# Altium schematic sheet StepperMotor.SchDoc
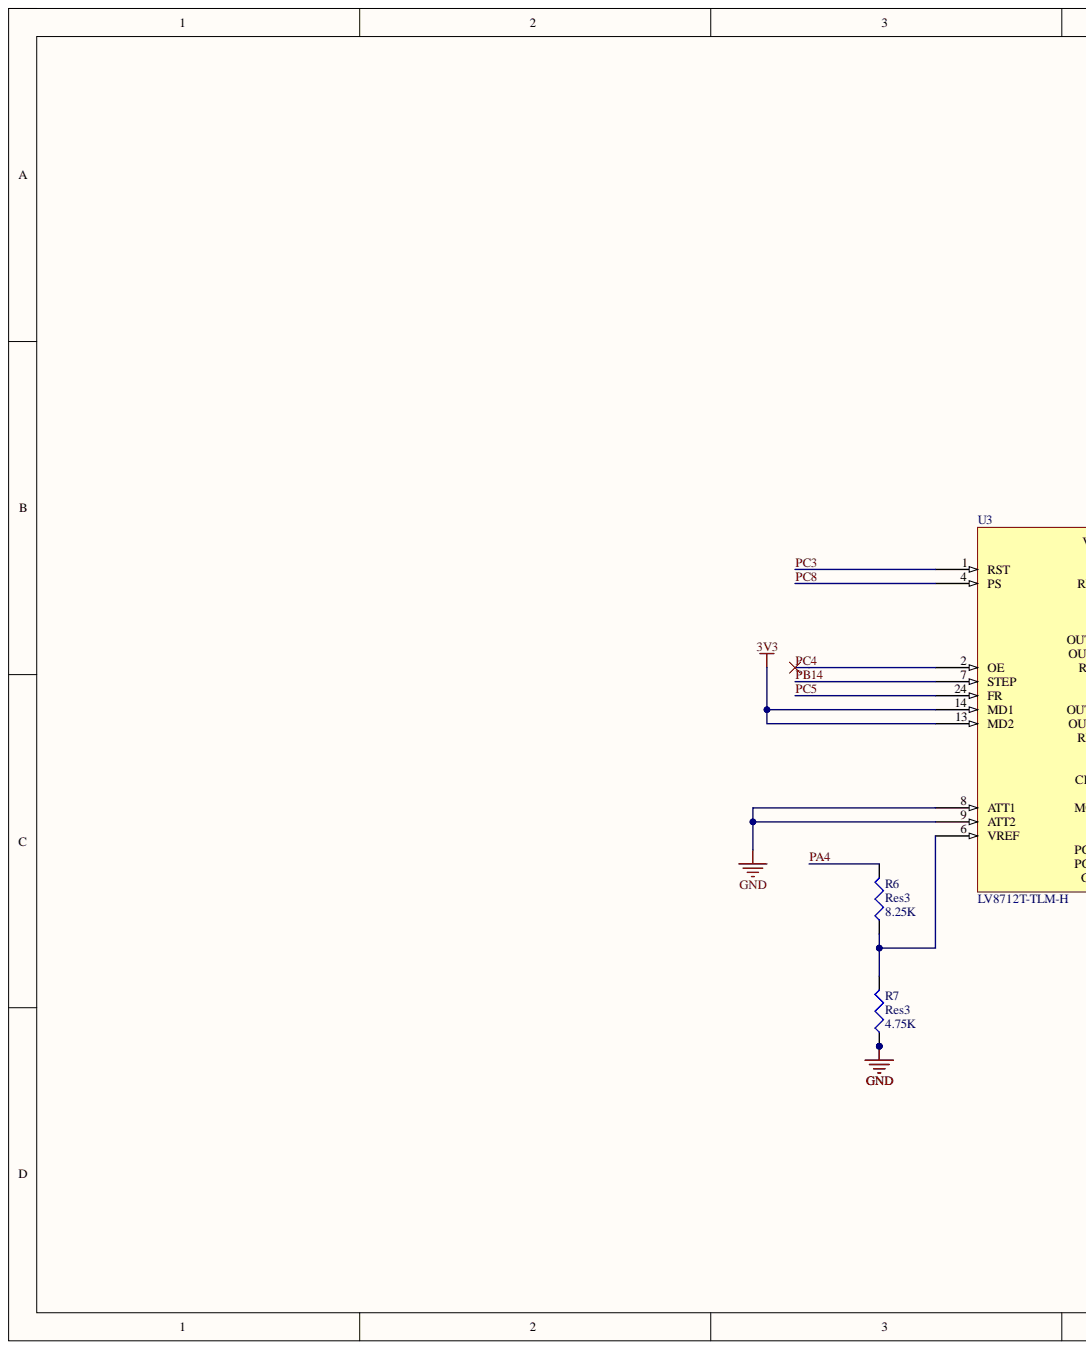
[diagram p0: StepperMotor.SchDoc, left]
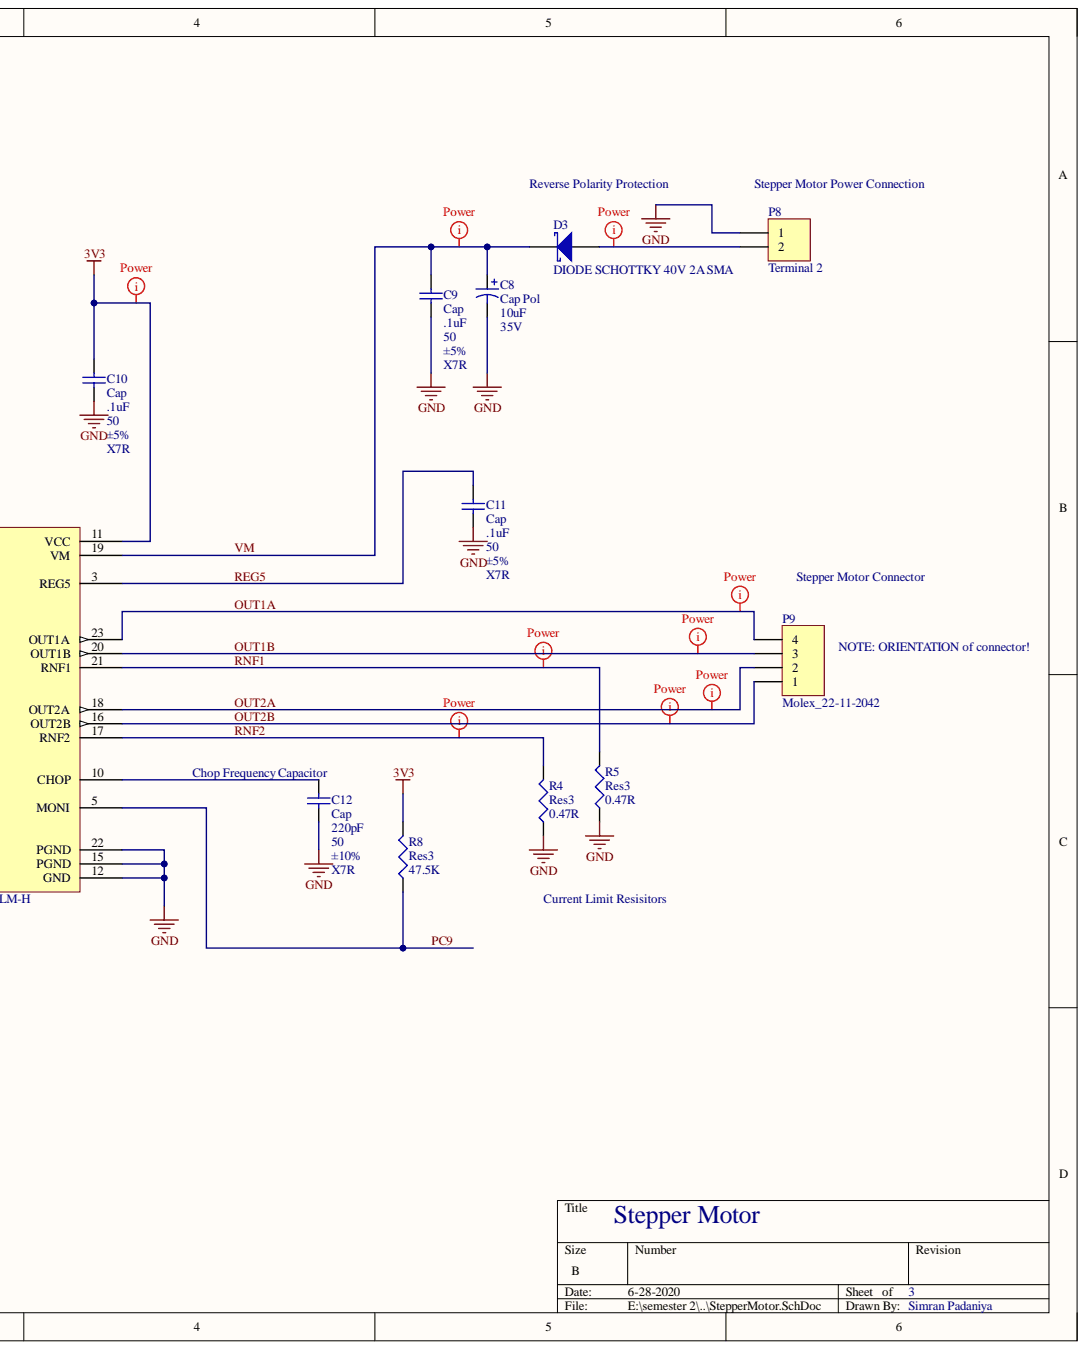
[diagram p1: StepperMotor.SchDoc, right]

## Parts
C8  Cap Pol  value 10uF  Voltage: 35V  [CAPACITOR_5MM]
C9  Cap  value .1uF  Tolerance: ±5%  [CAPC2012X09M]
C10  Cap  value .1uF  Tolerance: ±5%  [CAPC2012X09M]
C11  Cap  value .1uF  Tolerance: ±5%  [CAPC2012X09M]
C12  Cap  value 220pF  Tolerance: ±10%  [CAPC2012X09M]
D3  DIODE SCHOTTKY 40V 2A SMA  [DIOM4326X23M]
P8  Terminal 2  [TYCO_282841_2]
P9  Molex_22-11-2042  [MOLEX-22-11-2042]
R4  Res3  value 0.47R  [RESC3125X07M]
R5  Res3  value 0.47R  [RESC3125X07M]
R6  Res3  value 8.25K  [RESC2112X05M]
R7  Res3  value 4.75K  [RESC2112X05M]
R8  Res3  value 47.5K  [RESC2112X05M]
U3  LV8712T-TLM-H  [ONSEMITSSOP50P640X120-24M]

## Nets
3V3: C10.2 R8.2 U3.11(VCC) U3.13(MD2) U3.14(MD1)
GND: C8.2 C9.1 C10.1 C11.1 C12.1 P8.1 R4.1 R5.1 R7.1 U3.8(ATT1) U3.9(ATT2) U3.12(GND) U3.15(PGND) U3.22(PGND)
OUT1A: P9.4 U3.23(OUT1A)
OUT1B: P9.3 U3.20(OUT1B)
OUT2A: P9.2 U3.18(OUT2A)
OUT2B: P9.1 U3.16(OUT2B)
PA4: R6.2
PB14: U3.7(STEP)
PC3: U3.1(RST)
PC4: U3.2(OE)
PC5: U3.24(FR)
PC8: U3.4(PS)
PC9: R8.1 U3.5(MONI)
REG5: C11.2 U3.3(REG5)
RNF1: R5.2 U3.21(RNF1)
RNF2: R4.2 U3.17(RNF2)
VM: C8.1 C9.2 D3.1 U3.19(VM)
NetC12_2: C12.2 U3.10(CHOP)
NetD3_2: D3.2 P8.2
NetR6_1: R6.1 R7.2 U3.6(VREF)

## Library data of the parts
C8 = Cap Polar-10uF-35V: CAP 85C 10uF ±20% 35V WX Series Aluminum Electrolytic; MPN: UWX1V100MCL1GB; Leakage Current: 3.5; PN: 493-2117-1-ND; Rated Ripple Current: 29; Tan Theta: 0.12; Component Kind: Standard; Diameter: 5; Library Name: esd_library.IntLib; Name: Cap Polar-10uF-35V; URL: http://www.digikey.ca/product-detail/en/UWX1V100MCL1GB/493-2117-1-ND
C9-C11 = CAP-X7R-.1uF-50V-±5%: CAP CER .1uF 50v X7R 0805; MPN: C0805C104J5RACTU; PN: 399-1171-1-ND; WV: 50; Component Kind: Standard; Library Name: esd_library.IntLib; Name: CAP-X7R-.1uF-50V-±5%; Type: X7R; URL: http://www.digikey.ca/product-detail/en/C0805C104J5RACTU/399-1171-1-ND
C12 = CAP-X7R-220pF-50V-±10%: CAP CER 220pF 50v X7R 0805; MPN: C0805C221K5RACTU; PN: 399-1138-1-ND; WV: 50; Component Kind: Standard; Library Name: esd_library.IntLib; Name: CAP-X7R-220pF-50V-±10%; Type: X7R; URL: http://www.digikey.ca/product-detail/en/C0805C221K5RACTU/399-1138-1-ND
D3 = DIODE SCHOTTKY 40V 2A SMA: Schottky Rectifier; MPN: B240A-13-F; PN: B240A-FDICT-ND; Component Kind: Standard; Library Name: esd_library.IntLib; URL: http://www.digikey.ca/product-detail/en/B240A-13-F/B240A-FDICT-ND
P8 = Terminal 2: Terminal, 2-Pin; MPN: 282841-2; PN: A98342-ND; Component Kind: Standard; LatestRevisionDate: 17-Jul-2002; LatestRevisionNote: Re-released for DXP Platform.; Library Name: esd_library.IntLib; Signal Integrity: Connector; URL: http://www.digikey.ca/product-detail/en/282841-2/A98342-ND/1136740; Publisher: Altium Limited
P9 = Molex_22-11-2042: CONN HEADER 4POS .100 VERT GOLD; MPN: 0022112042; PN: WM2702-ND; Component Kind: Standard; Library Name: esd_library.IntLib; URL: http://www.digikey.ca/product-detail/en/0022112042/WM2702-ND
R4 R5 = Resistor_0.47R_1W: RES SMD 0.47OHM 5% 1W 1210; MPN: RCWE1210R470FKEA; PN: RCWE.47FCT-ND; Component Kind: Standard; Library Name: esd_library.IntLib; Name: Resistor_0.47R_1W; URL: http://www.digikey.ca/product-detail/en/vishay-dale/RCWE1210R470FKEA/RCWE.47FCT-ND
R6 = Resistor_8.25K: RES SMD 8.25K OHM 1% 1/8W 0805; MPN: CRCW08058K25FKEA; PN: 541-8.25KCCT-ND; Component Kind: Standard; Library Name: esd_library.IntLib; Name: Resistor_8.25K; URL: http://www.digikey.ca/product-detail/en/CRCW08058K25FKEA/541-8.25KCCT-ND
R7 = Resistor_4.75K: RES SMD 4.75K OHM 1% 1/8W 0805; MPN: CRCW08054K75FKEA; PN: 541-4.75KCCT-ND; Component Kind: Standard; Library Name: esd_library.IntLib; Name: Resistor_4.75K; URL: http://www.digikey.ca/product-detail/en/CRCW08054K75FKEA/541-4.75KCCT-ND
R8 = Resistor_47.5K: RES SMD 47.5K OHM 1% 1/8W 0805; MPN: CRCW080547K5FKEA; PN: 541-47.5KCCT-ND; Component Kind: Standard; Library Name: esd_library.IntLib; Name: Resistor_47.5K; URL: http://www.digikey.ca/product-detail/en/CRCW080547K5FKEA/541-47.5KCCT-ND
U3 = LV8712T-TLM-H: IC MOTOR DRIVER PAR 24TSSOP; PN: 869-1290-1-ND; Component Kind: Standard; Library Name: esd_library.IntLib; MPN: LV8712T-TLM-H; URL: http://www.digikey.ca/product-detail/en/on-semiconductor/LV8712T-TLM-H/869-1290-1-ND
